# Altium schematic sheet [05] INERTIAL SENSOR.SchDoc("[05] INERTIAL SENSOR")
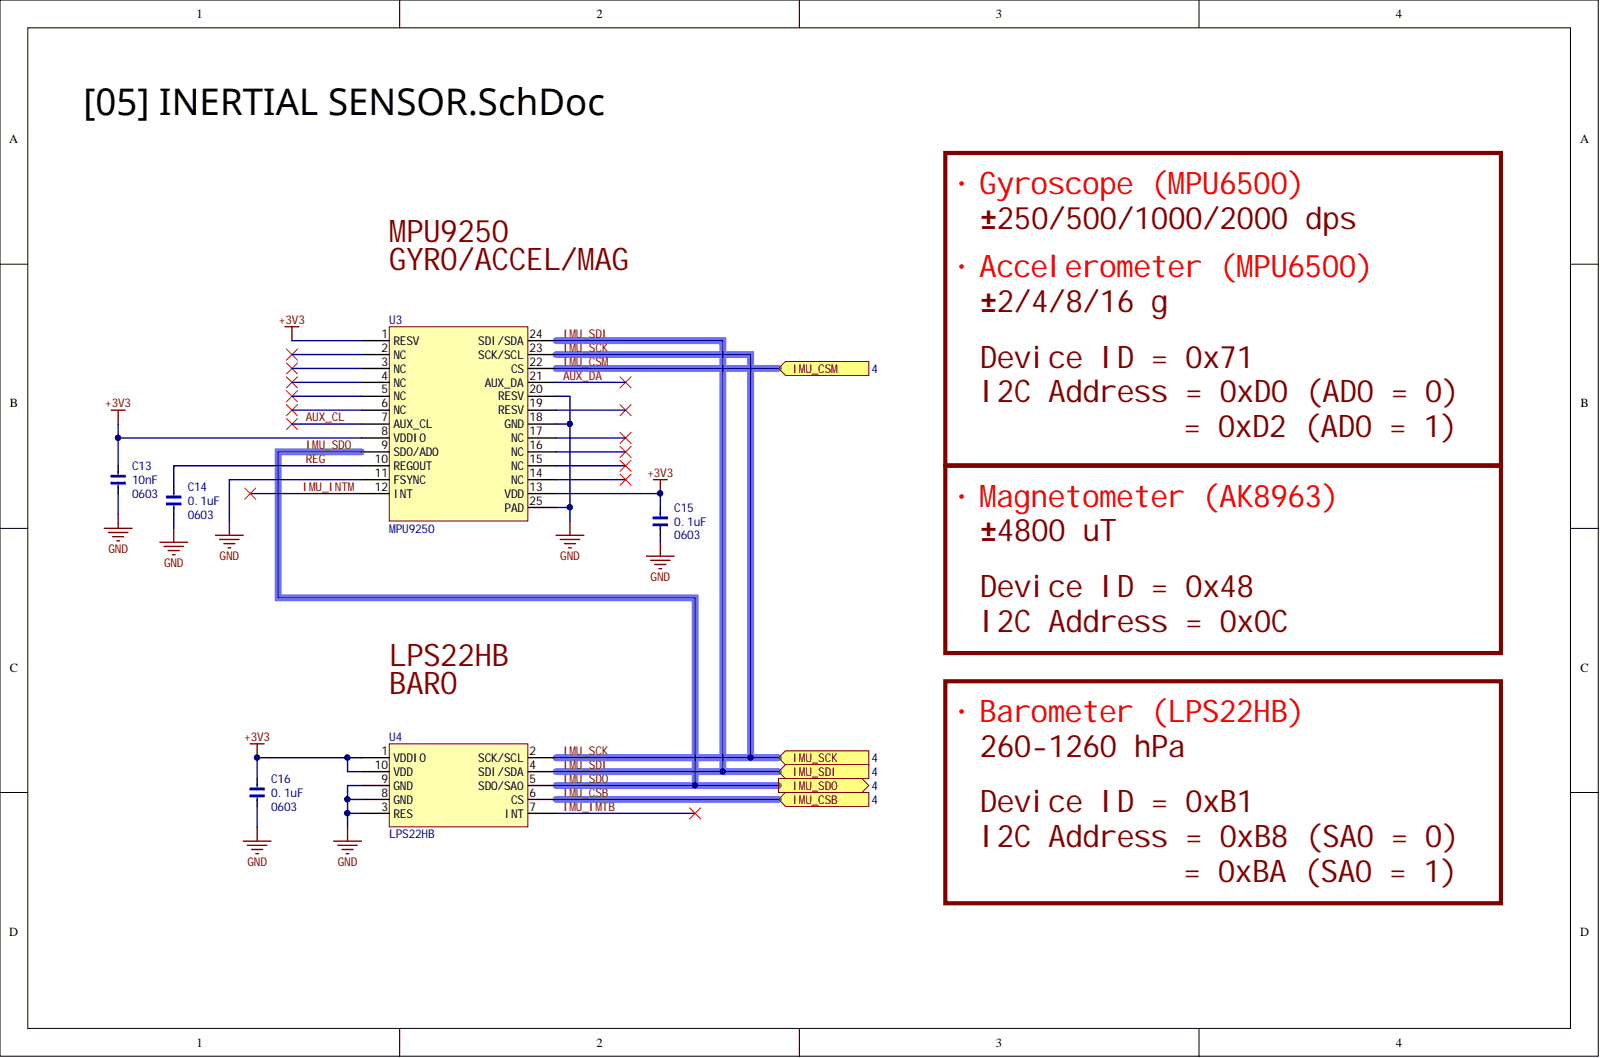
[diagram p0: [05] INERTIAL SENSOR.SchDoc]

## Parts
C13  10nF  Type: 0603  [CAP_0603]
C14  0.1uF  Type: 0603  [CAP_0603]
C15  0.1uF  Type: 0603  [CAP_0603]
C16  0.1uF  Type: 0603  [CAP_0603]
U3  MPU9250  [QFN_P0.4_24]
U4  LPS22HB  [HCLGA-2x2_10]

## Ports
IMU_CSB, IMU_CSM, IMU_SCK, IMU_SDI, IMU_SDO

## Nets
+3V3: C13.2 C15.2 C16.2 U3.1(RESV) U3.8(VDDIO) U3.13(VDD) U4.1(VDDIO) U4.10(VDD)
GND: C13.1 C14.1 C15.1 C16.1 U3.11(FSYNC) U3.18(GND) U3.20(RESV) U3.25(PAD) U4.3(RES) U4.8(GND) U4.9(GND)
AUX_CL: U3.7(AUX_CL)
AUX_DA: U3.21(AUX_DA)
IMU_CSB: U4.6(CS) ; port IMU_CSB
IMU_CSM: U3.22(CS) ; port IMU_CSM
IMU_IMTB: U4.7(INT)
IMU_INTM: U3.12(INT)
IMU_SCK: U3.23(SCK/SCL) U4.2(SCK/SCL) ; port IMU_SCK
IMU_SDI: U3.24(SDI/SDA) U4.4(SDI/SDA) ; port IMU_SDI
IMU_SDO: U3.9(SDO/AD0) U4.5(SDO/SA0) ; port IMU_SDO
REG: C14.2 U3.10(REGOUT)

Not connected: U3 2(NC) 3(NC) 4(NC) 5(NC) 6(NC) 14(NC) 15(NC) 16(NC) 17(NC) 19(RESV)

## Library data of the parts
C13-C16 = Cap_0603: Capacitor; Library Name: SCH_Passive.SchLib; Component Kind: Standard
  C13: Value: 10nF
  C14-C16: Value: 0.1uF
U3 = MPU925x: Sensor Motion; Type: QFN24 P0.4; Library Name: SCH_IntegratedCircuit.SchLib; Component Kind: Standard
U4 = LPS22HB: Sensor Pressure; Type: LGA10 P0.5; Library Name: SCH_IntegratedCircuit.SchLib; Component Kind: Standard
